# Altium schematic sheet main.SchDoc
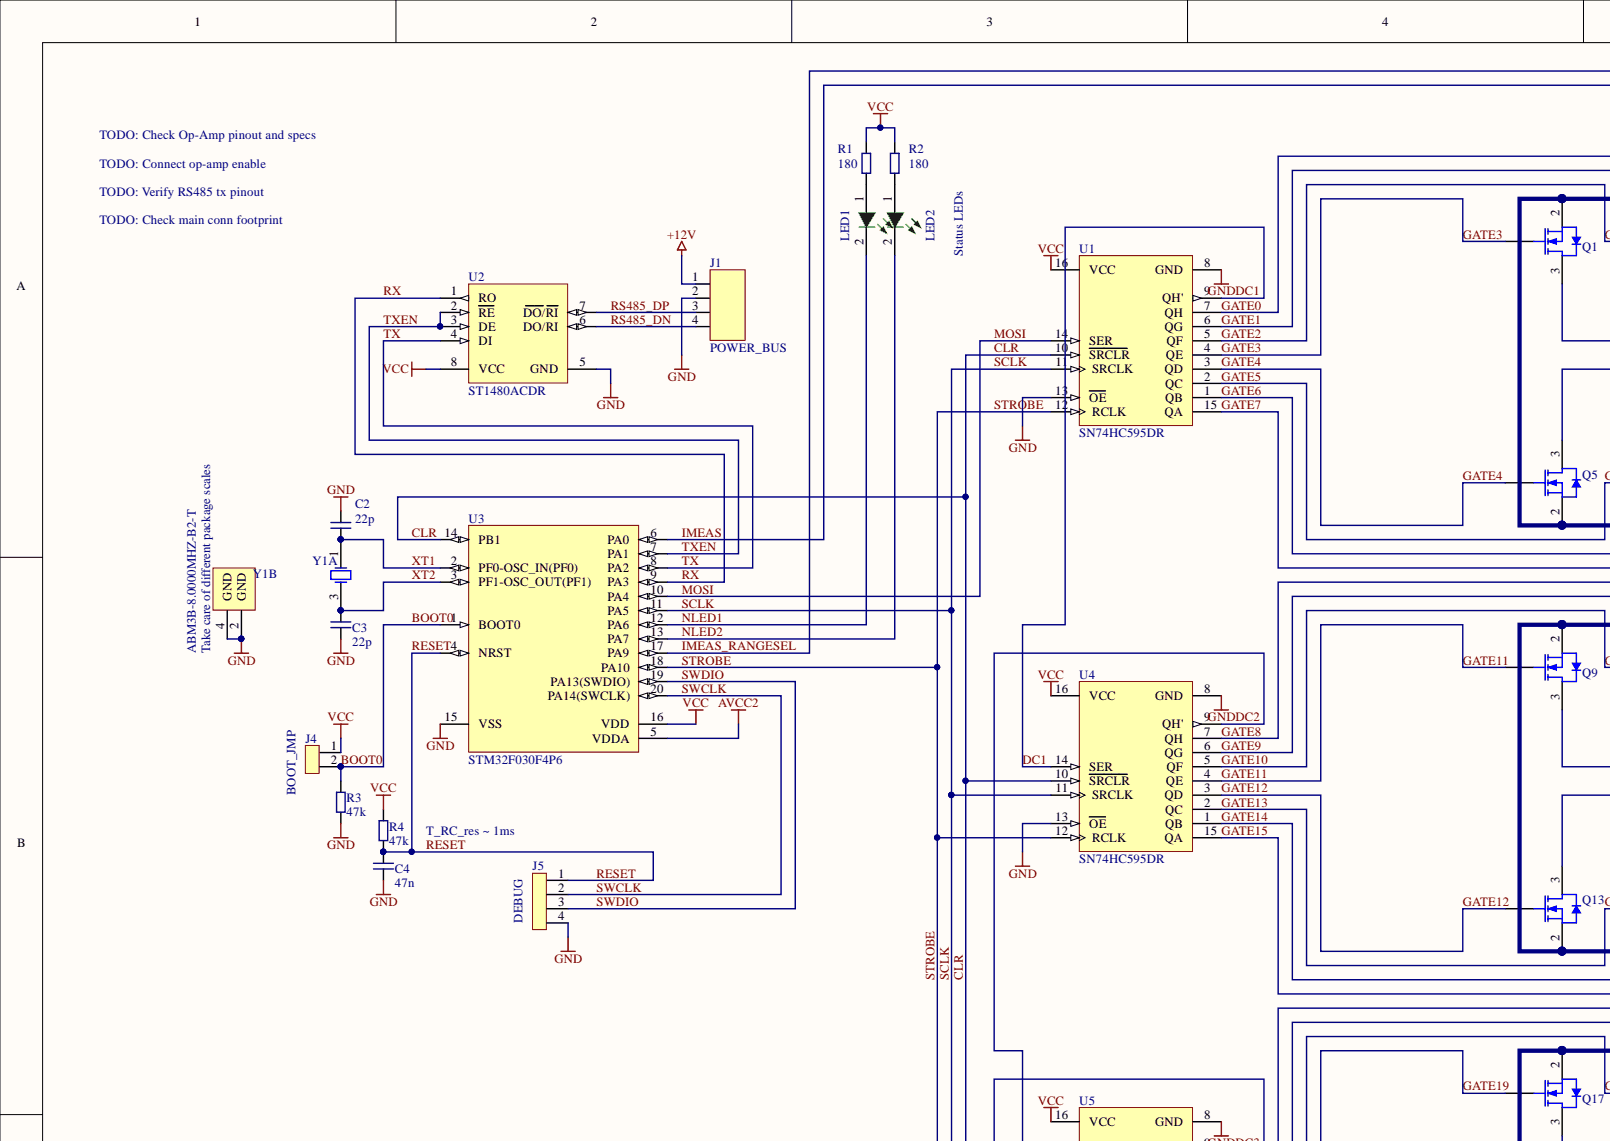
[diagram p0: main.SchDoc, top left]
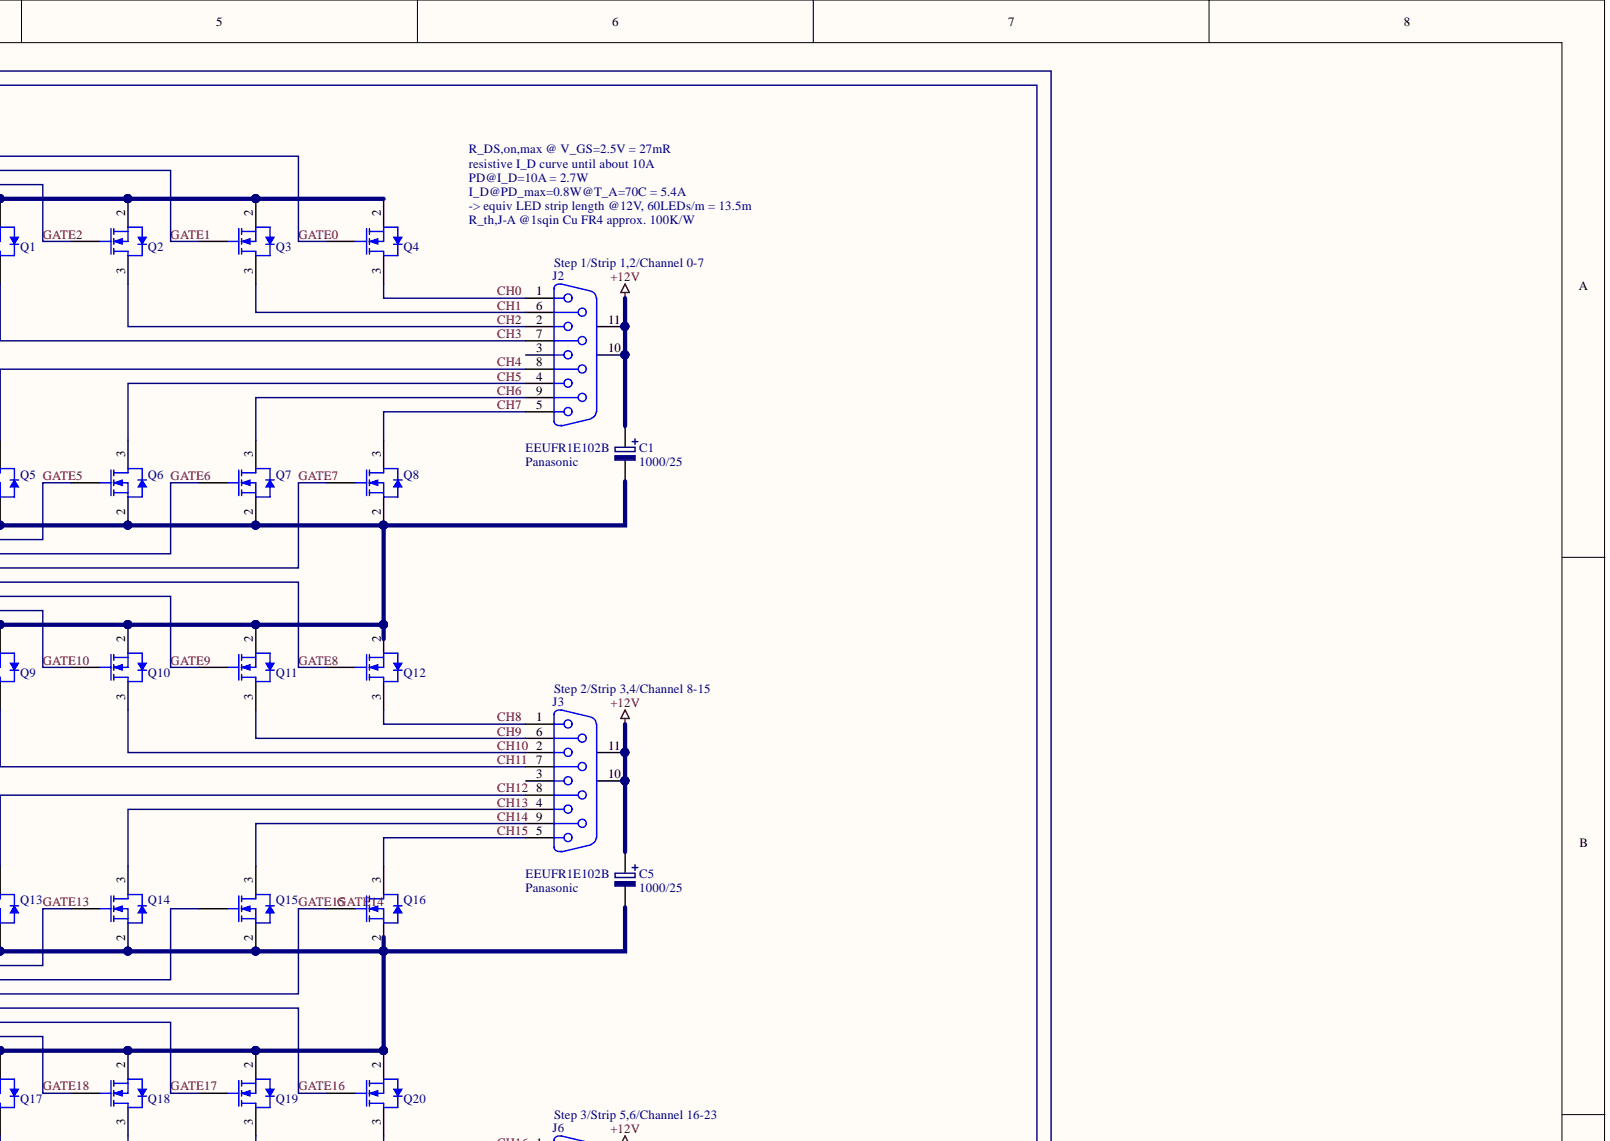
[diagram p1: main.SchDoc, top right]
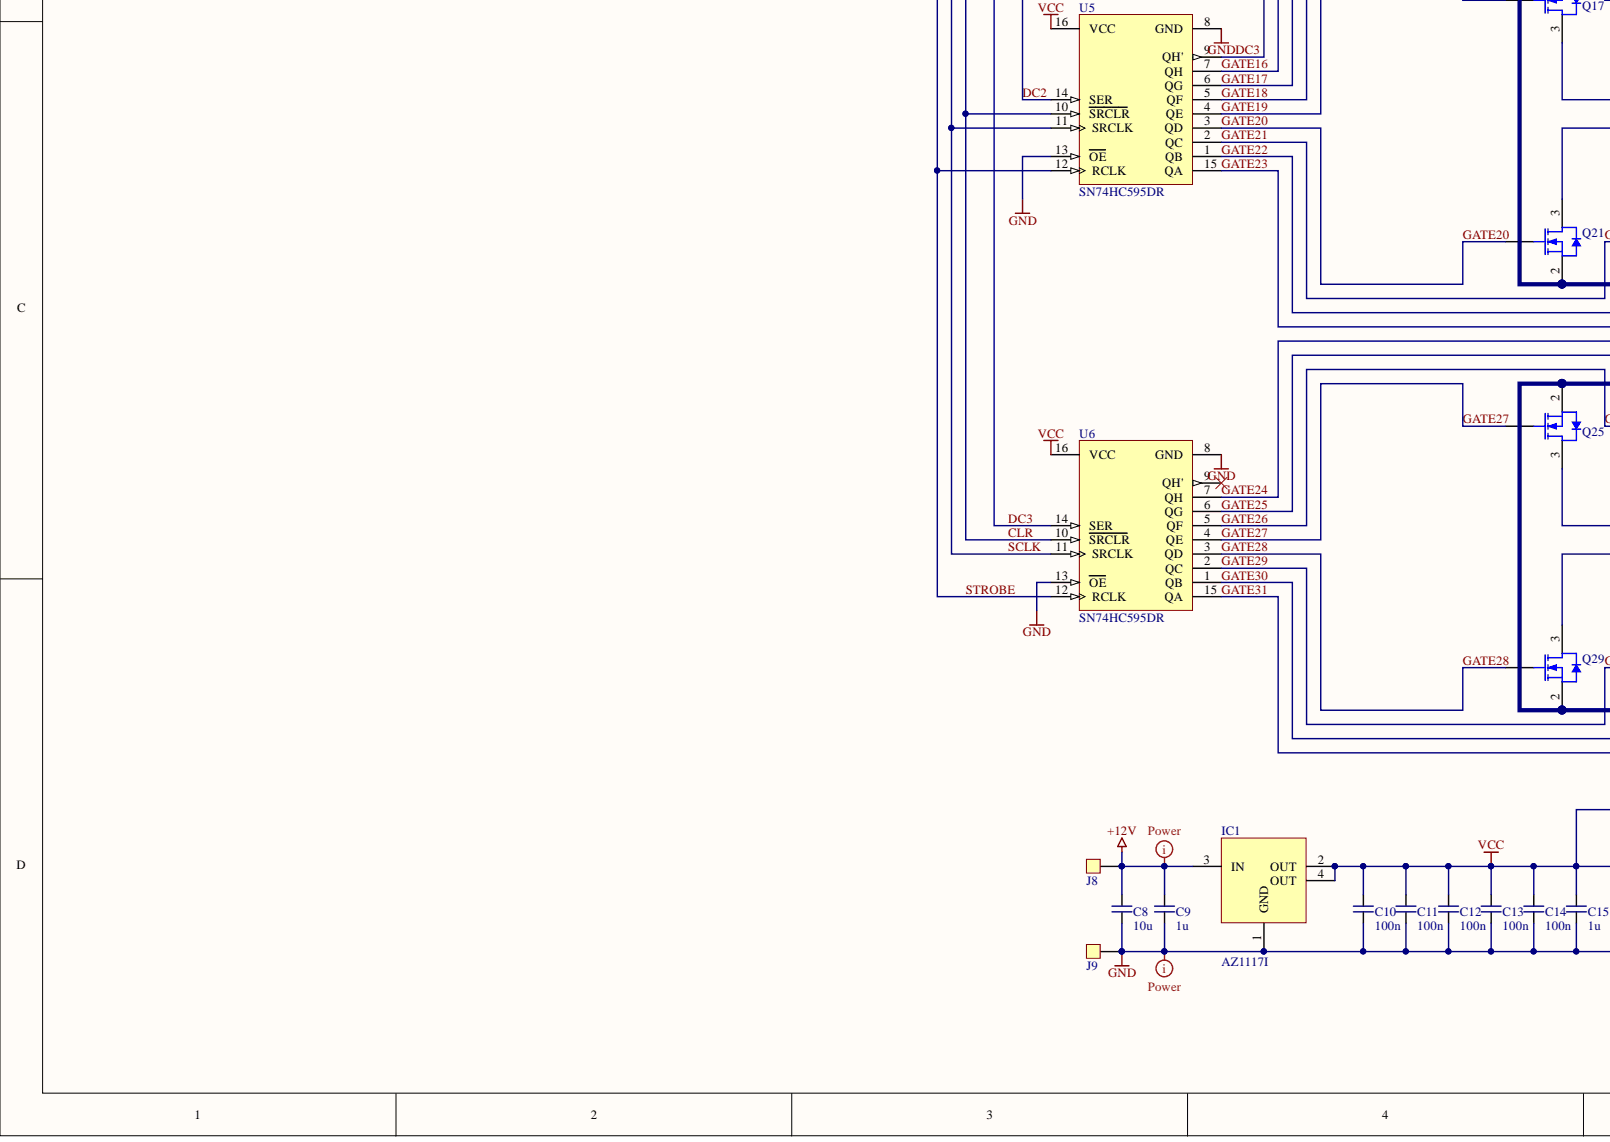
[diagram p2: main.SchDoc, bottom left]
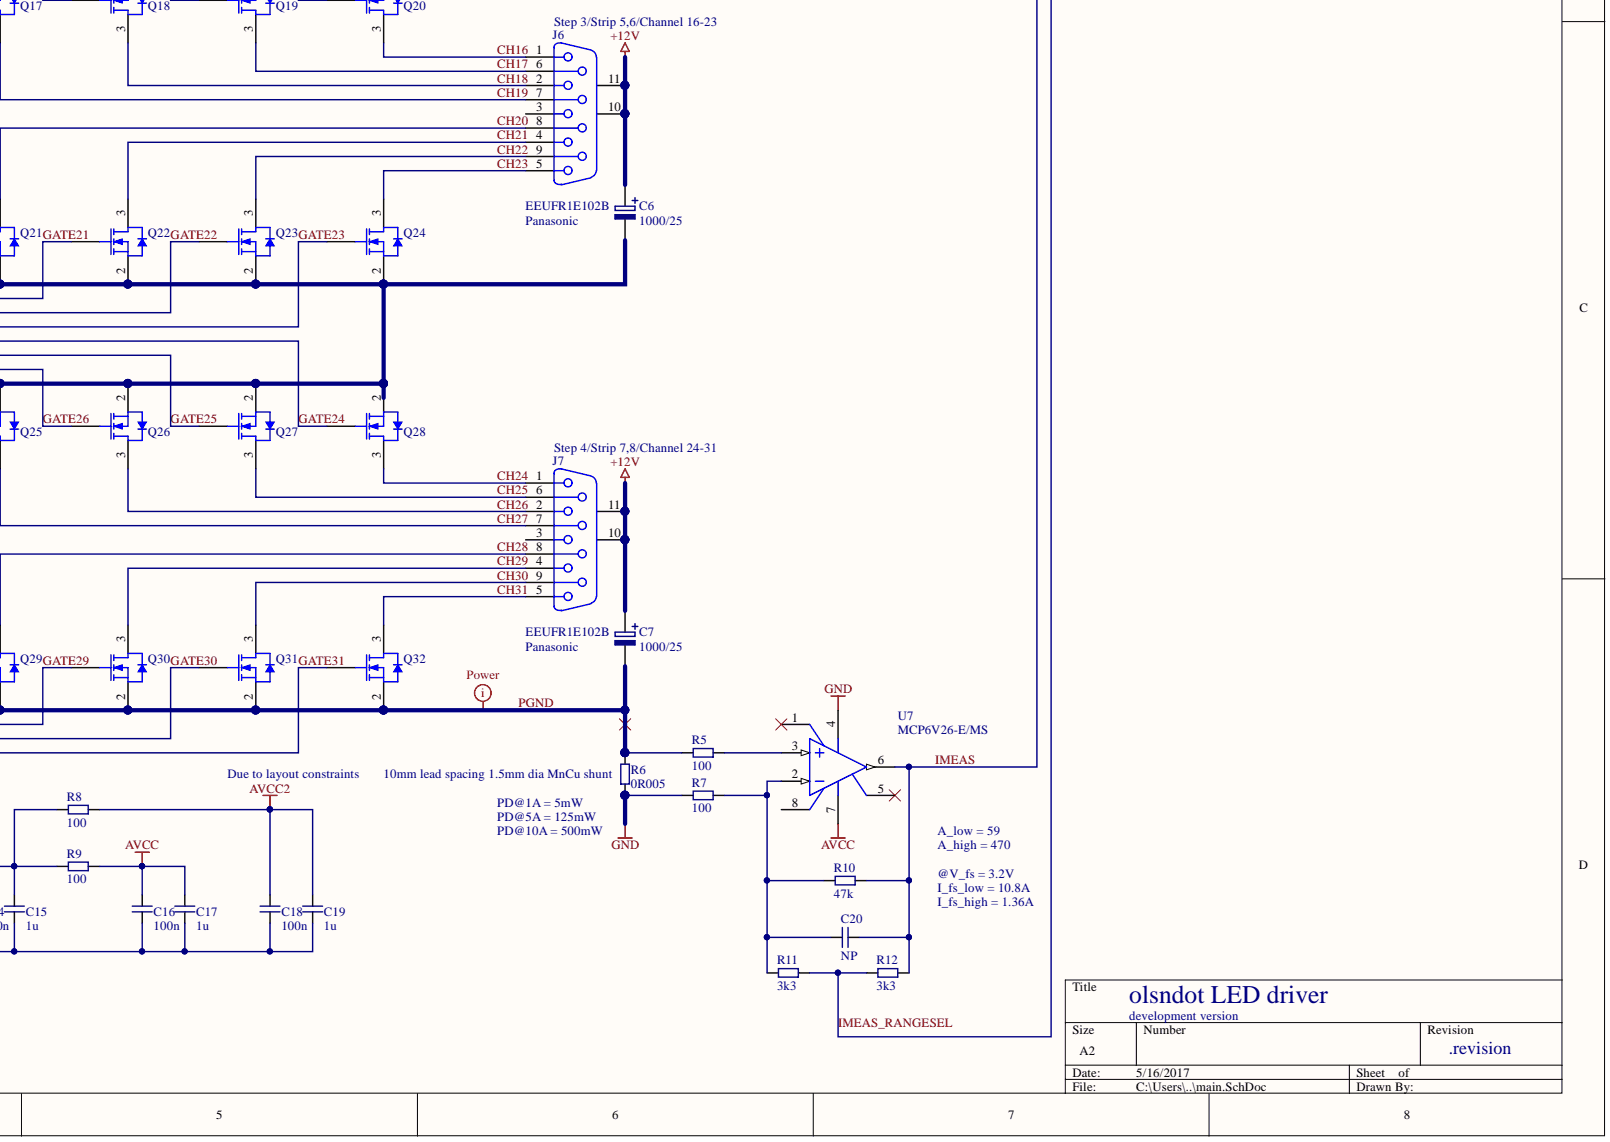
[diagram p3: main.SchDoc, bottom right]

## Parts
C1  1000/25  Mfg Part No: EEUFR1E102B  [ELKO_10mm_RM5]
C2  22p  [J1-0603]
C3  22p  [J1-0603]
C4  47n  [J1-0603]
C5  1000/25  Mfg Part No: EEUFR1E102B  [ELKO_10mm_RM5]
C6  1000/25  Mfg Part No: EEUFR1E102B  [ELKO_10mm_RM5]
C7  1000/25  Mfg Part No: EEUFR1E102B  [ELKO_10mm_RM5]
C8  10u  [6-0805_M]
C9  1u  [6-0805_M]
C10  100n  [J1-0603]
C11  100n  [J1-0603]
C12  100n  [J1-0603]
C13  100n  [J1-0603]
C14  100n  [J1-0603]
C15  1u  [6-0805_M]
C16  100n  [J1-0603]
C17  1u  [6-0805_M]
C18  100n  [J1-0603]
C19  1u  [J1-0603]
C20  NP  [J1-0603]
IC1  AZ1117I  [SOT223_M]
J1  POWER_BUS  [TB_5.08_4P_FLANGE]
J2  Step 1/Strip 1,2/Channel 0-7  [DSUB1.385-2H9]
J3  Step 2/Strip 3,4/Channel 8-15  [DSUB1.385-2H9]
J4  BOOT_JMP  [JUMPER_2P]
J5  DEBUG  [PINHD_4x1_100mil]
J6  Step 3/Strip 5,6/Channel 16-23  [DSUB1.385-2H9]
J7  Step 4/Strip 7,8/Channel 24-31  [DSUB1.385-2H9]
J8  +12V  [FS-P_6.35]
J9  GND  [FS-P_6.35]
LED1  KP-1608MGC  [KING-0603LED_GREEN_V]  (value not drawn)
LED2  KP-1608MGC  [KING-0603LED_GREEN_V]  (value not drawn)
Q1  IRLML6244TRPbF  [ONSC-SOT-23-3-318-08_V]  (value not drawn)
Q2  IRLML6244TRPbF  [ONSC-SOT-23-3-318-08_V]  (value not drawn)
Q3  IRLML6244TRPbF  [ONSC-SOT-23-3-318-08_V]  (value not drawn)
Q4  IRLML6244TRPbF  [ONSC-SOT-23-3-318-08_V]  (value not drawn)
Q5  IRLML6244TRPbF  [ONSC-SOT-23-3-318-08_V]  (value not drawn)
Q6  IRLML6244TRPbF  [ONSC-SOT-23-3-318-08_V]  (value not drawn)
Q7  IRLML6244TRPbF  [ONSC-SOT-23-3-318-08_V]  (value not drawn)
Q8  IRLML6244TRPbF  [ONSC-SOT-23-3-318-08_V]  (value not drawn)
Q9  IRLML6244TRPbF  [ONSC-SOT-23-3-318-08_V]  (value not drawn)
Q10  IRLML6244TRPbF  [ONSC-SOT-23-3-318-08_V]  (value not drawn)
Q11  IRLML6244TRPbF  [ONSC-SOT-23-3-318-08_V]  (value not drawn)
Q12  IRLML6244TRPbF  [ONSC-SOT-23-3-318-08_V]  (value not drawn)
Q13  IRLML6244TRPbF  [ONSC-SOT-23-3-318-08_V]  (value not drawn)
Q14  IRLML6244TRPbF  [ONSC-SOT-23-3-318-08_V]  (value not drawn)
Q15  IRLML6244TRPbF  [ONSC-SOT-23-3-318-08_V]  (value not drawn)
Q16  IRLML6244TRPbF  [ONSC-SOT-23-3-318-08_V]  (value not drawn)
Q17  IRLML6244TRPbF  [ONSC-SOT-23-3-318-08_V]  (value not drawn)
Q18  IRLML6244TRPbF  [ONSC-SOT-23-3-318-08_V]  (value not drawn)
Q19  IRLML6244TRPbF  [ONSC-SOT-23-3-318-08_V]  (value not drawn)
Q20  IRLML6244TRPbF  [ONSC-SOT-23-3-318-08_V]  (value not drawn)
Q21  IRLML6244TRPbF  [ONSC-SOT-23-3-318-08_V]  (value not drawn)
Q22  IRLML6244TRPbF  [ONSC-SOT-23-3-318-08_V]  (value not drawn)
Q23  IRLML6244TRPbF  [ONSC-SOT-23-3-318-08_V]  (value not drawn)
Q24  IRLML6244TRPbF  [ONSC-SOT-23-3-318-08_V]  (value not drawn)
Q25  IRLML6244TRPbF  [ONSC-SOT-23-3-318-08_V]  (value not drawn)
Q26  IRLML6244TRPbF  [ONSC-SOT-23-3-318-08_V]  (value not drawn)
Q27  IRLML6244TRPbF  [ONSC-SOT-23-3-318-08_V]  (value not drawn)
Q28  IRLML6244TRPbF  [ONSC-SOT-23-3-318-08_V]  (value not drawn)
Q29  IRLML6244TRPbF  [ONSC-SOT-23-3-318-08_V]  (value not drawn)
Q30  IRLML6244TRPbF  [ONSC-SOT-23-3-318-08_V]  (value not drawn)
Q31  IRLML6244TRPbF  [ONSC-SOT-23-3-318-08_V]  (value not drawn)
Q32  IRLML6244TRPbF  [ONSC-SOT-23-3-318-08_V]  (value not drawn)
R1  180  [J1-0603]
R2  180  [J1-0603]
R3  47k  [J1-0603]
R4  47k  [J1-0603]
R5  100  [J1-0603]
R6  0R005  [10x1.5mm_Shunt]
R7  100  [J1-0603]
R8  100  [J1-0603]
R9  100  [J1-0603]
R10  47k  [J1-0603]
R11  3k3  [J1-0603]
R12  3k3  [J1-0603]
U1  SN74HC595DR  [D0016A_M]
U2  ST1480ACDR  [SO8_M]
U3  STM32F030F4P6  [STM-TSSOP20_L]
U4  SN74HC595DR  [D0016A_M]
U5  SN74HC595DR  [D0016A_M]
U6  SN74HC595DR  [D0016A_M]
U7  MCP6V26-E/MS  [MSOP-MS8_N]
Y1  ABM3B-8.0000MHZ-B2-T  Note: Take care of different package scales  [XTAL_MJ_5x3.2]  (parts A,B)

## Nets
+12V: C1.1 C5.1 C6.1 C7.1 C8.1 C9.1 IC1.3(IN) J1.1 J2.10 J2.11 J3.10 J3.11 J6.10 J6.11 J7.10 J7.11 J8.1
AVCC: C16.1 C17.1 R9.2 U7.7
AVCC2: C18.1 C19.1 R8.2 U3.5(VDDA)
GND: C2.1 C3.2 C4.2 C8.2 C9.2 C10.2 C11.2 C12.2 C13.2 C14.2 C15.2 C16.2 C17.2 C18.2 C19.2 IC1.1(GND) J1.2 J5.4 J9.1 R3.1 R6.2 R7.1 U1.8(GND) U1.13(OE) U2.5(GND) U3.15(VSS) U4.8(GND) U4.13(OE) U5.8(GND) U5.13(OE) U6.8(GND) U6.13(OE) U7.4 Y1.2(GND) Y1.4(GND)
VCC: C10.1 C11.1 C12.1 C13.1 C14.1 C15.1 IC1.2(OUT) IC1.4(OUT) J4.1 R1.2 R2.2 R4.2 R8.1 R9.1 U1.16(VCC) U2.8(VCC) U3.16(VDD) U4.16(VCC) U5.16(VCC) U6.16(VCC)
BOOT0: J4.2 R3.2 U3.1(BOOT0)
CH0: J2.1 Q4.3
CH1: J2.6 Q3.3
CH2: J2.2 Q2.3
CH3: J2.7 Q1.3
CH4: J2.8 Q5.3
CH5: J2.4 Q6.3
CH6: J2.9 Q7.3
CH7: J2.5 Q8.3
CH8: J3.1 Q12.3
CH9: J3.6 Q11.3
CH10: J3.2 Q10.3
CH11: J3.7 Q9.3
CH12: J3.8 Q13.3
CH13: J3.4 Q14.3
CH14: J3.9 Q15.3
CH15: J3.5 Q16.3
CH16: J6.1 Q20.3
CH17: J6.6 Q19.3
CH18: J6.2 Q18.3
CH19: J6.7 Q17.3
CH20: J6.8 Q21.3
CH21: J6.4 Q22.3
CH22: J6.9 Q23.3
CH23: J6.5 Q24.3
CH24: J7.1 Q28.3
CH25: J7.6 Q27.3
CH26: J7.2 Q26.3
CH27: J7.7 Q25.3
CH28: J7.8 Q29.3
CH29: J7.4 Q30.3
CH30: J7.9 Q31.3
CH31: J7.5 Q32.3
CLR: U1.10(SRCLR) U3.14(PB1) U4.10(SRCLR) U5.10(SRCLR) U6.10(SRCLR)
DC1: U1.9(QH') U4.14(SER)
DC2: U4.9(QH') U5.14(SER)
DC3: U5.9(QH') U6.14(SER)
GATE0: Q4.1 U1.7(QH)
GATE1: Q3.1 U1.6(QG)
GATE2: Q2.1 U1.5(QF)
GATE3: Q1.1 U1.4(QE)
GATE4: Q5.1 U1.3(QD)
GATE5: Q6.1 U1.2(QC)
GATE6: Q7.1 U1.1(QB)
GATE7: Q8.1 U1.15(QA)
GATE8: Q12.1 U4.7(QH)
GATE9: Q11.1 U4.6(QG)
GATE10: Q10.1 U4.5(QF)
GATE11: Q9.1 U4.4(QE)
GATE12: Q13.1 U4.3(QD)
GATE13: Q14.1 U4.2(QC)
GATE14: Q15.1 U4.1(QB)
GATE15: Q16.1 U4.15(QA)
GATE16: Q20.1 U5.7(QH)
GATE17: Q19.1 U5.6(QG)
GATE18: Q18.1 U5.5(QF)
GATE19: Q17.1 U5.4(QE)
GATE20: Q21.1 U5.3(QD)
GATE21: Q22.1 U5.2(QC)
GATE22: Q23.1 U5.1(QB)
GATE23: Q24.1 U5.15(QA)
GATE24: Q28.1 U6.7(QH)
GATE25: Q27.1 U6.6(QG)
GATE26: Q26.1 U6.5(QF)
GATE27: Q25.1 U6.4(QE)
GATE28: Q29.1 U6.3(QD)
GATE29: Q30.1 U6.2(QC)
GATE30: Q31.1 U6.1(QB)
GATE31: Q32.1 U6.15(QA)
IMEAS: C20.2 R10.2 R12.2 U3.6(PA0) U7.6
IMEAS_RANGESEL: R11.2 R12.1 U3.17(PA9)
MOSI: U1.14(SER) U3.10(PA4)
NLED1: LED1.2 U3.12(PA6)
NLED2: LED2.2 U3.13(PA7)
PGND: C1.2 C5.2 C6.2 C7.2 Q1.2 Q2.2 Q3.2 Q4.2 Q5.2 Q6.2 Q7.2 Q8.2 Q9.2 Q10.2 Q11.2 Q12.2 Q13.2 Q14.2 Q15.2 Q16.2 Q17.2 Q18.2 Q19.2 Q20.2 Q21.2 Q22.2 Q23.2 Q24.2 Q25.2 Q26.2 Q27.2 Q28.2 Q29.2 Q30.2 Q31.2 Q32.2 R5.1 R6.1
RESET: C4.1 J5.1 R4.1 U3.4(NRST)
RS485_DN: J1.4 U2.6(DO/RI)
RS485_DP: J1.3 U2.7(DO/RI)
RX: U2.1(RO) U3.9(PA3)
SCLK: U1.11(SRCLK) U3.11(PA5) U4.11(SRCLK) U5.11(SRCLK) U6.11(SRCLK)
STROBE: U1.12(RCLK) U3.18(PA10) U4.12(RCLK) U5.12(RCLK) U6.12(RCLK)
SWCLK: J5.2 U3.20(PA14(SWCLK))
SWDIO: J5.3 U3.19(PA13(SWDIO))
TX: U2.4(DI) U3.8(PA2)
TXEN: U2.2(RE) U2.3(DE) U3.7(PA1)
XT1: C2.2 U3.2(PF0-OSC_IN(PF0)) Y1.1
XT2: C3.1 U3.3(PF1-OSC_OUT(PF1)) Y1.3
NetC20_1: C20.1 R7.2 R10.1 R11.1 U7.2
NetLED1_1: LED1.1 R1.1
NetLED2_1: LED2.1 R2.1
NetR5_2: R5.2 U7.3

Not connected: J2 3; J3 3; J6 3; J7 3; U6 9(QH'); U7 1 5 8

## Library data of the parts
C1 C5-C7 = ELKO: Mfg: Panasonic; Component Kind: Standard; Library Name: components.SchLib
C2-C4 C8-C20 = C: Capacitor; Component Kind: Standard; Library Name: components.SchLib
IC1 = AZ1117I: LDO, industrial temp range; Component Kind: Standard; Library Name: components.SchLib
J1 = Phoenix_PT1,5/4-5,0H: Component Kind: Standard; Library Name: components.SchLib
J2 J3 J6 J7 = D Connector 9: Receptacle Assembly, 9 Position, Right Angle; PackageDocument: O; Positions: 9; Component Kind: Standard; DatasheetDocument: 1-Sep-1999; Gender: Receptacle; LatestRevisionDate: 17-Jul-2002; LatestRevisionNote: Re-released for DXP Platform.; Library Name: Miscellaneous Connectors.IntLib; Orientation: Right Angle; PackageReference: 788750; Published: 15-Jun-2001; Signal Integrity: Connector; Publisher: Altium Limited
J4 = JUMPER: Component Kind: Standard; Description: Jumper; Library Name: components.SchLib
J5 = PH_4x1: Pin Header 4x1 pin; Component Kind: Standard; Library Name: components.SchLib
J8 J9 = TESTPOINT: Component Kind: Standard; Library Name: components.SchLib
LED1 LED2 = CMP-0404-00001-1: LED, SMT, 0603(1608), 1.1mm thickness, Green; Manufacturer: Kingbright; Forward Current: 30 mA; Packing Type: 2000 pcs / reel; Power Dissipation: 75 mW; Reverse Voltage: 5 V; Component Kind: Standard; ComponentLink1Description: Manufacturer URL; ComponentLink1URL: http://www.kingbright.com/; Library Name: components.SchLib; Mounting Technology: Surface Mount; PackageDescription: 2-Pin SMT LED, 1.6 x 0.8 mm Pitch; PackageReference: 0603LED_GREEN; PartNumber: KP-1608MGC; Storage Temperature: -40 to +85 degC
Q1-Q32 = CMP-1058-00794-1: Power MOSFET, 500 mA, 60 V, N-Channel, 3-Pin SOT-23, Pb-Free, Tape and Reel; Manufacturer: On Semiconductor; PartNumber: NVBF170LT1G; Channel Polarity: N Channel; Configuration: Single; ID Max (A): 0.5; PD Max (W): 0.225; V(BR)DSS Min (V): 60; VGS(th) Max (V): 3; VGS Max (V): 20; Component Kind: Standard; ComponentLink1Description: Manufacturer URL; ComponentLink1URL: http://www.onsemi.com/; ComponentLink2Description: Datasheet; ComponentLink2URL: http://www.onsemi.cn/pub_link/Collateral/MMBF170LT1-D.PDF; ComponentLink3Description: Package Specification; ComponentLink3URL: http://www.onsemi.cn/pub_link/Collateral/318-08.PDF; DatasheetVersion: Rev. 9; Library Name: Altium Content Vault; Mounting Technology: Surface Mount; PackageDescription: 3-Pin Small Outline Transistor, Body 2.92 x 1.3 mm, Pitch 0.95 mm; PackageReference: SOT-23-3-318-08; Package Type: SOT-23-3; PackageVersion: Rev. AP, 11/2009; Packing: Tape and Reel; RoHS: Pb-Free
R1-R5 R7-R12 = R: Resistor; Component Kind: Standard; Library Name: components.SchLib
R6 = R: Resistor; mechanical: 10mm lead spacing 1.5mm dia MnCu shunt; Component Kind: Standard; Library Name: components.SchLib
U1 U4-U6 = CMP-0780-00037-3: 8-Bit Shift Registers With 3-State Output Registers, D0016A, LARGE T&R; Manufacturer: Texas Instruments; 3-State Output: Yes; Bits: 4; F @ Nom Voltage(Max)(Mhz): 28; ICC @ Nom Voltage(Max)(mA): 0.08; Input Type: CMOS; Output Drive (IOL/IOH)(Max)(mA): 7.8/-7.8; Rating: Military; Technology Family: HC; tpd @ Nom Voltage(Max)(ns): 34; VCC(Max)(V): 6; VCC(Min)(V): 2; Code_JEDEC: MS-012 variation AC; Component Kind: Standard; DatasheetVersion: SCLS041H; Generic Part Number: SN74HC595; Library Name: Altium Content Vault; Operating Temperature Range(C): -40 to 85; Output Type: CMOS; PackageReference: D0016A; PackageVersion: http://www.ti.com/lit/ml/mpds178g/mpds178g.pdf; Voltage(Nom)(V): 6
U2 = IN-LNTX-DS75176B: Multipoint RS-485/RS-422 Transceiver, 8-pin Narrow SOIC; Manufacturer: National Semiconductor; CompType: Interface IC; PackageTopMarking: NSZXTT*DS751*76BM; PowerWise: N; Code_IPC: SOIC127P600-8; Code_JEDEC: MS-012-AA; Component Kind: Standard; DatasheetVersion: Jul-2004; Library Name: components.SchLib; PackageDescription: SOIC Narrow, 4.9 x 3.9 x 1.45mm, 8 Lead, 1.27mm Pitch; PackageReference: M08A; PackageVersion: revM, May-2009; PinCount: 8; RoHS: N
U3 = CMP-0304-00039-2: ARM Cortex-M0 32-bit MCU, 16 KB Flash, 4 KB Internal RAM, 15 I/Os, 20-pin TSSOP, -40 to 85 degC, Tube; FLASH Size (Prog) (kB): 16; I/Os (High Current): 15; Internal RAM Size (kB): 4; Operating Frequency(F) (Processor speed) (MHz): 48; Supply Voltage(Vcc) min (V): 2.4; Component Kind: Standard; ComponentLink1Description: Manufacturer URL; ComponentLink1URL: http://www.st.com/web/en/home.html; ComponentLink2Description: Datasheet; ComponentLink2URL: http://www.st.com/st-web-ui/static/active/en/resource/technical/document/datasheet/DM00088500.pdf; ComponentLink3Description: Package Specification; ComponentLink3URL: http://www.st.com/st-web-ui/static/active/en/resource/technical/document/datasheet/DM00065136.pdf; Core: ARM Cortex-M0; DatasheetVersion: Rev. 1; Library Name: Altium Content Vault; Mounting Technology: Surface Mount; PackageDescription: 20-Pin Thin Shrink Small Outline Package, 6.5 x 4.4 mm Body, 0.65 mm Pitch; PackageReference: TSSOP20; PackageVersion: Rev. 1, 11/2012; Packing: Tube; PartNumber: STM32F030F4P6
U7 = CMP-0187-00815-1: 620 Î¼A, 2 MHz Auto-Zeroed Op Amp, 8-Pin MSOP, Extended Temperature; Component Kind: Standard; ComponentLink1Description: Manufacturer URL; ComponentLink1URL: http://www.microchip.com/; ComponentLink2Description: Datasheet; ComponentLink2URL: http://ww1.microchip.com/downloads/en/DeviceDoc/25007B.pdf; ComponentLink3Description: Package Specification; ComponentLink3URL: http://www.microchip.com/stellent/groups/techpub_sg/documents/packagingspec/en012702.pdf; DatasheetVersion: revB, Aug-2011; Library Name: Altium Content Vault; PackageDescription: 8-Lead Plastic Micro Small Outline Package (MS) [MSOP]; PackageReference: MSOP-MS8; PackageVersion: revBB, Aug-2009
Y1 = ABRA-ABM3B-4: Crystal, SMD, 25.000000MHz Stab=50ppm 18.0pF; Manufacturer: Abracon; PartNumber: ABM3B-25.000MHZ-B2-T; Body Shape: Rectangular; Packing Type: Tape & Reel; Case Size: 5.0 x 3.2 mm; Component Kind: Standard; ComponentLink1Description: Manufacturer URL; ComponentLink1URL: http://www.abracon.com/; ComponentLink2Description: Datasheet; ComponentLink2URL: http://www.abracon.com/Resonators/abm3b.pdf; DatasheetVersion: Apr-13; Library Name: components.SchLib; Mounting Technology: Surface Mount; PackageDescription: 4-Pin SMT Crystal, 5.2 x 3.4 mm Body; PackageReference: ABM3B; Storage Temperature: -40 to 80 degC
